# Altium schematic sheet MCU_V3.0.SchDoc
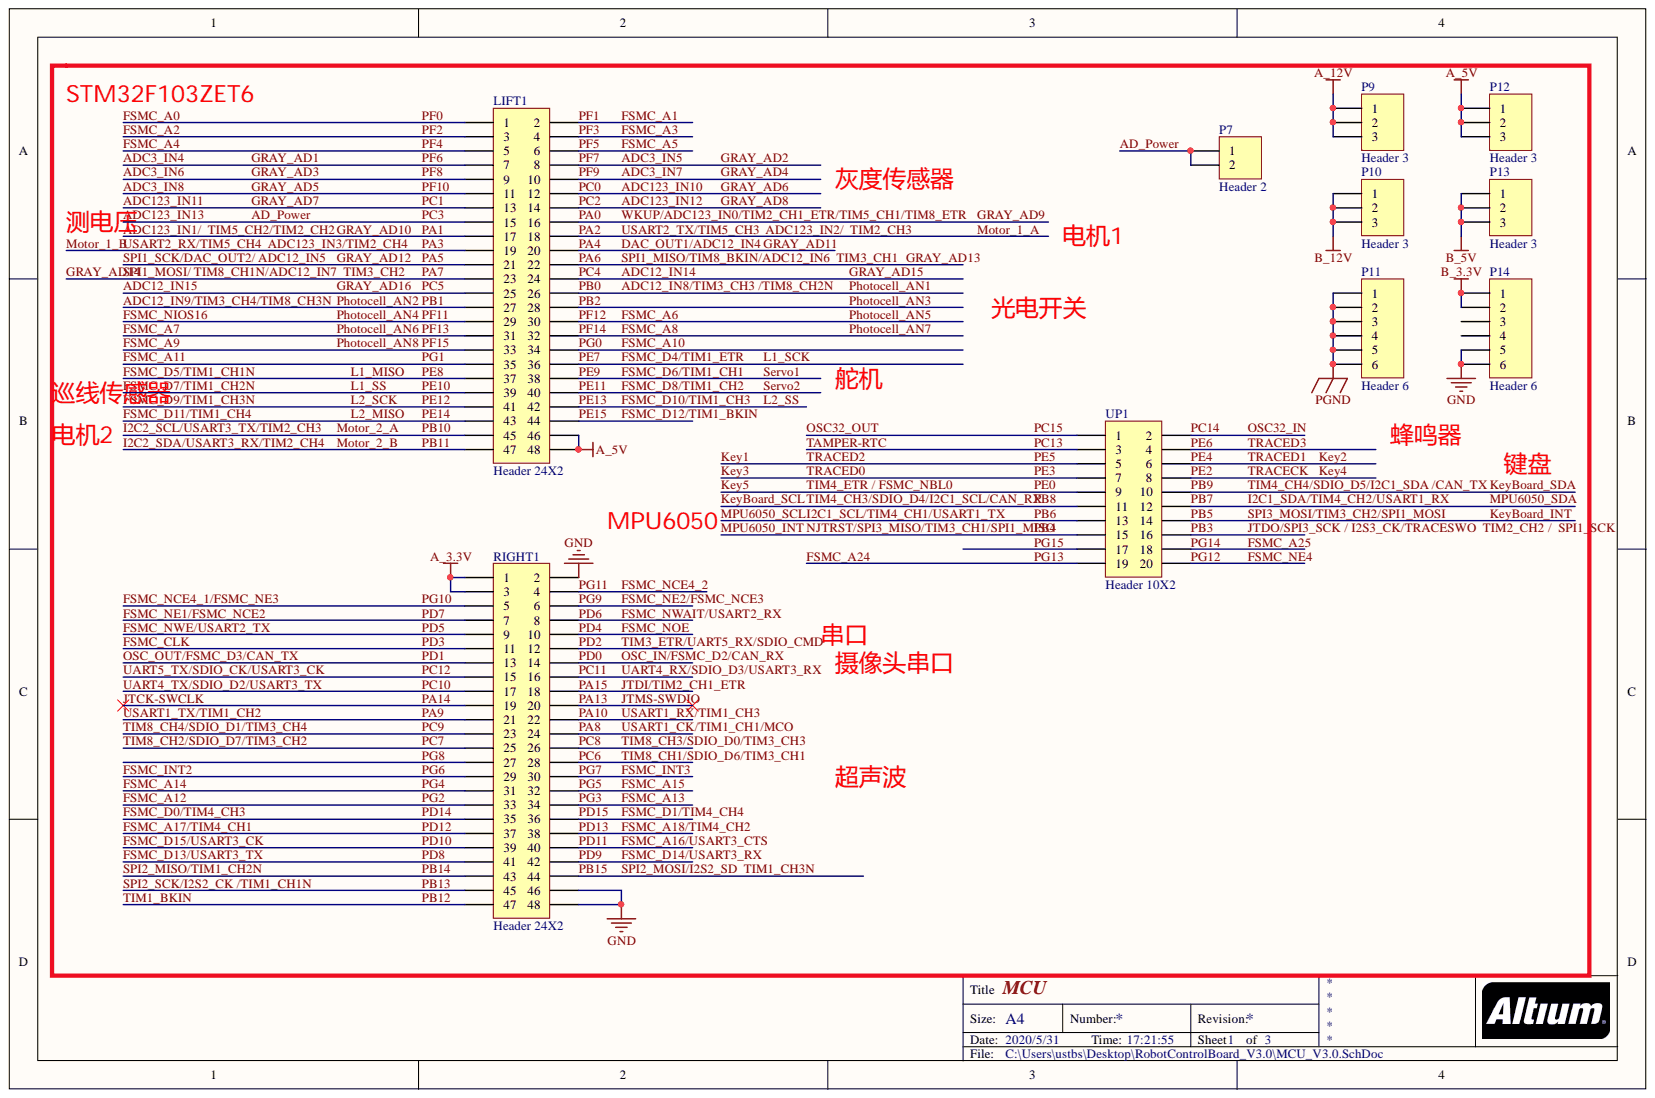
[diagram p0: MCU_V3.0.SchDoc]

## Parts
LIFT1  Header 24X2  [HDR2X24]
P7  Header 2  [HDR1X2]
P9  Header 3  [HDR1X3]
P10  Header 3  [HDR1X3]
P11  Header 6  [HDR1X6]
P12  Header 3  [HDR1X3]
P13  Header 3  [HDR1X3]
P14  Header 6  [HDR1X6]
RIGHT1  Header 24X2  [HDR2X24]
UP1  Header 10X2  [HDR2X10]

## Nets
A_3.3V: RIGHT1.1 RIGHT1.3
A_5V: LIFT1.46 LIFT1.48 P12.1 P12.2 P12.3
A_12V: P9.1 P9.2 P9.3
B_3.3V: P14.1 P14.2
B_5V: P13.1 P13.2 P13.3
B_12V: P10.1 P10.2 P10.3
GND: P14.5 P14.6 RIGHT1.2 RIGHT1.46 RIGHT1.48
PGND: P11.1 P11.2 P11.3 P11.4 P11.5 P11.6
AD_Power: LIFT1.15 P7.1 P7.2
ADC3_IN4: LIFT1.7
ADC3_IN5: LIFT1.8
ADC3_IN6: LIFT1.9
ADC3_IN7: LIFT1.10
ADC3_IN8: LIFT1.11
ADC12_IN8/TIM3_CH3 /TIM8_CH2N: LIFT1.26
ADC12_IN9/TIM3_CH4/TIM8_CH3N: LIFT1.27
ADC12_IN14: LIFT1.24
ADC12_IN15: LIFT1.25
ADC123_IN1/  TIM5_CH2/TIM2_CH2: LIFT1.17
ADC123_IN10: LIFT1.12
ADC123_IN11: LIFT1.13
ADC123_IN12: LIFT1.14
DAC_OUT1/ADC12_IN4: LIFT1.20
FSMC_A0: LIFT1.1
FSMC_A1: LIFT1.2
FSMC_A2: LIFT1.3
FSMC_A3: LIFT1.4
FSMC_A4: LIFT1.5
FSMC_A5: LIFT1.6
FSMC_A6: LIFT1.30
FSMC_A7: LIFT1.31
FSMC_A8: LIFT1.32
FSMC_A9: LIFT1.33
FSMC_A10: LIFT1.34
FSMC_A11: LIFT1.35
FSMC_A12: RIGHT1.33
FSMC_A13: RIGHT1.34
FSMC_A14: RIGHT1.31
FSMC_A15: RIGHT1.32
FSMC_A16/USART3_CTS: RIGHT1.40
FSMC_A17/TIM4_CH1: RIGHT1.37
FSMC_A18/TIM4_CH2: RIGHT1.38
FSMC_A24: UP1.19
FSMC_A25: UP1.18
FSMC_CLK: RIGHT1.11
FSMC_D0/TIM4_CH3: RIGHT1.35
FSMC_D1/TIM4_CH4: RIGHT1.36
FSMC_D4/TIM1_ETR: LIFT1.36
FSMC_D5/TIM1_CH1N: LIFT1.37
FSMC_D6/TIM1_CH1: LIFT1.38
FSMC_D7/TIM1_CH2N: LIFT1.39
FSMC_D8/TIM1_CH2: LIFT1.40
FSMC_D9/TIM1_CH3N: LIFT1.41
FSMC_D10/TIM1_CH3: LIFT1.42
FSMC_D11/TIM1_CH4: LIFT1.43
FSMC_D12/TIM1_BKIN: LIFT1.44
FSMC_D13/USART3_TX: RIGHT1.41
FSMC_D14/USART3_RX: RIGHT1.42
FSMC_D15/USART3_CK: RIGHT1.39
FSMC_INT2: RIGHT1.29
FSMC_INT3: RIGHT1.30
FSMC_NCE4_1/FSMC_NE3: RIGHT1.5
FSMC_NCE4_2: RIGHT1.4
FSMC_NE1/FSMC_NCE2: RIGHT1.7
FSMC_NE2/FSMC_NCE3: RIGHT1.6
FSMC_NE4: UP1.20
FSMC_NIOS16: LIFT1.29
FSMC_NOE: RIGHT1.10
FSMC_NWAIT/USART2_RX: RIGHT1.8
FSMC_NWE/USART2_TX: RIGHT1.9
GRAY_AD9: LIFT1.16
GRAY_AD12: LIFT1.21
GRAY_AD13: LIFT1.22
GRAY_AD14: LIFT1.23
I2C1_SCL/TIM4_CH1/USART1_TX: UP1.13
I2C1_SDA/TIM4_CH2/USART1_RX: UP1.12
I2C2_SCL/USART3_TX/TIM2_CH3: LIFT1.45
I2C2_SDA/USART3_RX/TIM2_CH4: LIFT1.47
JTCK-SWCLK: RIGHT1.19
JTDI/TIM2_CH1_ETR: RIGHT1.18
JTDO/SPI3_SCK / I2S3_CK/TRACESWO  TIM2_CH2 /  SPI1_SCK: UP1.16
JTMS-SWDIO: RIGHT1.20
Key1: UP1.5
Key2: UP1.6
Key3: UP1.7
Key4: UP1.8
Key5: UP1.9
KeyBoard_INT: UP1.14
KeyBoard_SCL: UP1.11
KeyBoard_SDA: UP1.10
Motor_1_A: LIFT1.18
Motor_1_B: LIFT1.19
MPU6050_INT: UP1.15
OSC32_IN: UP1.2
OSC32_OUT: UP1.1
OSC_IN/FSMC_D2/CAN_RX: RIGHT1.14
OSC_OUT/FSMC_D3/CAN_TX: RIGHT1.13
PA8: RIGHT1.24
PA9: RIGHT1.21
PA10: RIGHT1.22
PB2: LIFT1.28
PB12: RIGHT1.47
PB13: RIGHT1.45
PB14: RIGHT1.43
PB15: RIGHT1.44
PC6: RIGHT1.28
PC7: RIGHT1.25
PC8: RIGHT1.26
PC9: RIGHT1.23
PC10: RIGHT1.17
PC11: RIGHT1.16
PC12: RIGHT1.15
PC13: UP1.3
PD2: RIGHT1.12
PE6: UP1.4
PG8: RIGHT1.27
PG15: UP1.17

Not connected: P14 3 4

## Library data of the parts
LIFT1 RIGHT1 = Header 24X2: Header, 24-Pin, Dual row; Component Kind: Standard; LatestRevisionDate: 17-Jul-2002; LatestRevisionNote: Re-released for DXP Platform.; Library Name: Miscellaneous Connectors.IntLib; Signal Integrity: Connector; Publisher: Altium Limited
P7 = Header 2: Header, 2-Pin; Component Kind: Standard; LatestRevisionDate: 17-Jul-2002; LatestRevisionNote: Re-released for DXP Platform.; Library Name: Miscellaneous Connectors.IntLib; Signal Integrity: Connector; Publisher: Altium Limited
P9 P10 P12 P13 = Header 3: Header, 3-Pin; Component Kind: Standard; LatestRevisionDate: 17-Jul-2002; LatestRevisionNote: Re-released for DXP Platform.; Library Name: Miscellaneous Connectors.IntLib; Signal Integrity: Connector; Publisher: Altium Limited
P11 P14 = Header 6: Header, 6-Pin; Component Kind: Standard; LatestRevisionDate: 17-Jul-2002; LatestRevisionNote: Re-released for DXP Platform.; Library Name: Miscellaneous Connectors.IntLib; Signal Integrity: Connector; Publisher: Altium Limited
UP1 = Header 10X2: Header, 10-Pin, Dual row; Component Kind: Standard; LatestRevisionDate: 17-Jul-2002; LatestRevisionNote: Re-released for DXP Platform.; Library Name: Miscellaneous Connectors.IntLib; Signal Integrity: Connector; Publisher: Altium Limited
